# Altium schematic sheet PWR.SchDoc(PWR)
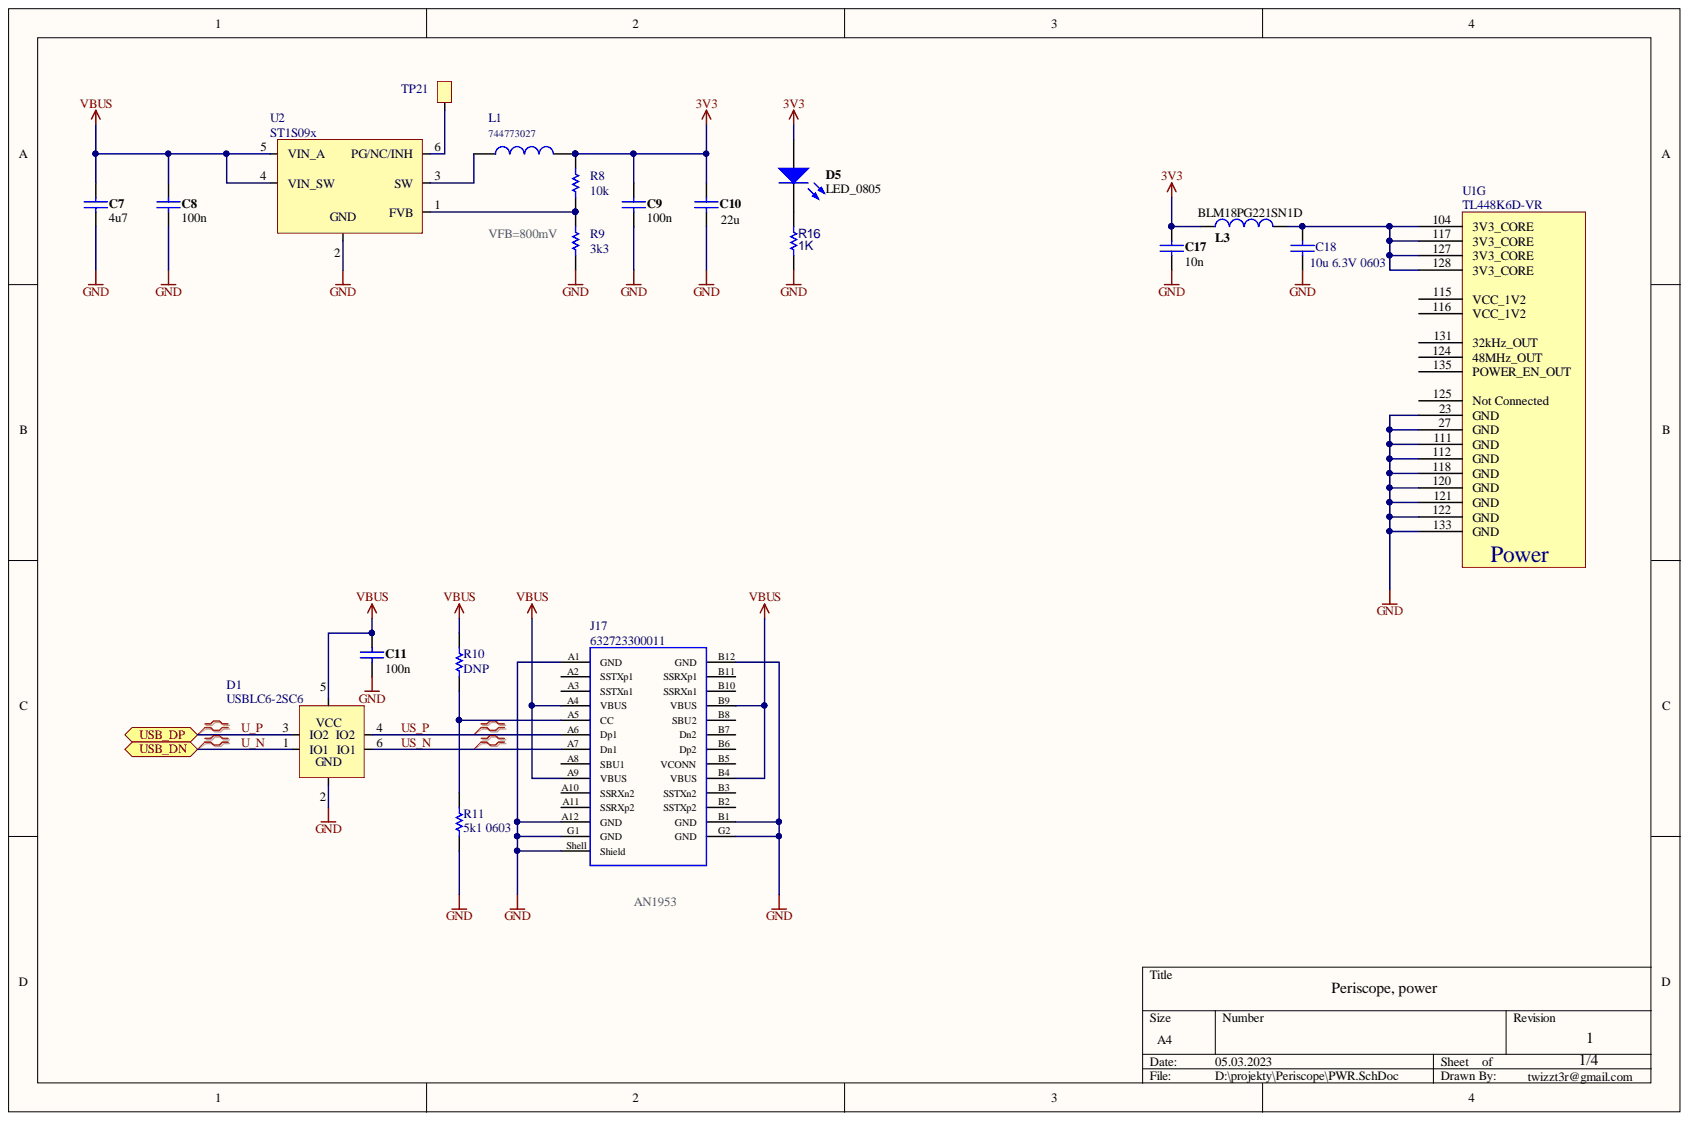
[diagram p0: PWR.SchDoc]

## Parts
C7  value 4u7  [C_0603]  (hidden comment: 4u7 10V 0603)
C8  value 100n  [C_0603]  (hidden comment: 100n 25V 0603)
C9  value 100n  [C_0603]  (hidden comment: 100n 25V 0603)
C10  value 22u  [C_0805]  (hidden comment: 22u 6V3 0805)
C11  value 100n  [C_0603]  (hidden comment: 100n 25V 0603)
C17  value 10n  [C_0603]  (hidden comment: 10n 50v 0603)  (net tie)
C18  10u 6.3V 0603  [WCAP-CSGP_0603_H=0.8mm_Reflow]
D1  USBLC6-2SC6  [SOT666]
D5  LED_0805  [D_0805]
J17  632723300011  [632723300011]
L1  744773027  [WE-PD2_4532]
L3  BLM18PG221SN1D  [R_0805]
R8  value 10k  [R_0603]  (hidden comment: 10k 0603)
R9  value 3k3  [R_0603]  (hidden comment: 3k3 0603)
R10  DNP  [R_0603]
R11  5k1 0603  [R_0603]
R16  VALUE_TXT: 1K  [R_0603]  (hidden comment: 1k 0603)
TP21  testpoint  [TP_round_1mm]  (value not drawn)
U1  TL448K6D-VR  [TL448K6D-VR]  (part G)
U2  ST1S09x  [QFN6]

## Ports
USB_DN, USB_DP

## Nets
3V3: C9.2 C10.2 C17.2 D5.1 L1.2 L3.2 R8.2
GND: C7.1 C8.1 C9.1 C10.1 C11.1 C17.1 C18.1 D1.2 J17.A1(GND) J17.A12(GND) J17.B1(GND) J17.B12(GND) J17.G1(GND) J17.G2(GND) J17.Shell(Shield) R9.1 R11.1 R16.1 U1.23(GND) U1.27(GND) U1.111(GND) U1.112(GND) U1.118(GND) U1.120(GND) U1.121(GND) U1.122(GND) U1.133(GND) U2.2
VBUS: C7.2 C8.2 C11.2 D1.5 J17.A4(VBUS) J17.A9(VBUS) J17.B4(VBUS) J17.B9(VBUS) R10.2 U2.4(VIN_SW) U2.5(VIN_A)
U_N: D1.1(IO1) ; port USB_DN
U_P: D1.3(IO2) ; port USB_DP
US_N: D1.6(IO1) J17.A7(Dn1)
US_P: D1.4(IO2) J17.A6(Dp1)
NetC18_2: C18.2 L3.1 U1.104(3V3_CORE) U1.117(3V3_CORE) U1.127(3V3_CORE) U1.128(3V3_CORE)
NetD5_2: D5.2 R16.2
NetJ17_A5: J17.A5(CC) R10.1 R11.2
NetL1_1: L1.1 U2.3(SW)
NetR8_1: R8.1 R9.2 U2.1(FVB)
NetTP21_1: TP21.1 U2.6(PG/NC/INH)

Not connected: J17 A2(SSTXp1) A3(SSTXn1) A8(SBU1) A10(SSRXn2) A11(SSRXp2) B2(SSTXp2) B3(SSTXn2) B5(VCONN) B6(Dp2) B7(Dn2) B8(SBU2) B10(SSRXn1) B11(SSRXp1); U1 115(VCC_1V2) 116(VCC_1V2) 124(48MHz_OUT) 125(Not_Connected) 131(32kHz_OUT) 135(POWER_EN_OUT)

## Library data of the parts
C7 = C_4u7_10v_0603: 4u7 10v; mfg_pn: CGB3B1X5R1A475M055AC; Library Name: SCH.SchLib; Component Kind: Standard
C8 C9 C11 = C_100n_25V_0603: 100n 25V 0603 X7R; mfg_pn: 885012206071; Library Name: SCH.SchLib; Component Kind: Standard
C10 = C_0805: 1206; Library Name: SCH.SchLib; Component Kind: Standard
C17 = C_10n_50v_0603: mfg_pn: CL10B103KB8NNNC; Rated Voltage: 50v; Library Name: SCH.SchLib; Description: 10n 50V 0603
C18 = C_10u_6V3_0603: WCAP-CSGP Multilayer Ceramic Chip Capacitor, General Purpose, size 0603, X5R, 10uF, 6.3V; Manufacturer Part Number: 885012106006; Manufacturer: Wurth Elektronik; Technical Reference: X5R0603106M6R3DFCT10000; Rated Voltage: 6.3VDC; Isolation Resistance  min.: 0.01GOhm; Dissipation Factor max.: 10%; Capacitance Tolerance: +/-20%; Size: 0603; Q-Factor min.: -; Published Date: April 2021; Packaging: Tape and Reel; Operating Temperature: -55 to +85degC; Mounting Technology: SMT; Library Name: SCH.SchLib; Height: 0.8mm; ComponentLink2URL: https://www.we-online.com/catalog/datasheet/885012106006.pdf; ComponentLink2Description: Datasheet; ComponentLink1URL: http://www.we-online.de; ComponentLink1Description: Manufacturer; Component Kind: Standard; Ceramic Type: X5R; Capacitance: 10uF
D5 = LED_0805: LED; mfg_pn: LL-S170VC-V1-1B; Library Name: SCH.SchLib; Component Kind: Standard
J17 = 632723300011: USB 3.1 Type C, Receptacle, Horizontal, THR; Manufacturer: Wurth Elektronik; Working Voltage: 20VAC; Rated Current: 5A; Pin Number: 24; Interface Type: Type C; Type: Horizontal; Published Date: August 2020; Packaging: Tape and Reel; Operating Temperature: -40 to +105degC; Mounting Technology: THR; Manufacturer Part Number: 632723300011; Library Name: SCH.SchLib; Gender: Receptacle; ComponentLink2URL: https://katalog.we-online.com/em/datasheet/632723300011.pdf; ComponentLink2Description: Datasheet; ComponentLink1URL: http://www.we-online.de; ComponentLink1Description: Manufacturer; Component Kind: Standard; Application: USB 3.1
L1 = 744773027: WE-PD2 SMT Power Inductor, size 4532, 2.7uH, 2.25A; Manufacturer: Wurth Elektronik; Self Resonant Frequency: 72MHz; Saturation Current: 2.97A; DC Resistance typ.: 0.039Ohm; DC Resistance max.: 0.079Ohm; Size: 4532; Rated Current: 2.25A; Published Date: April 2020; Packaging: Tape and Reel; Operating Temperature: -40 to +125degC; Mounting Technology: SMT; Manufacturer Part Number: 744773027; Library Name: SCH.SchLib; Inductance: 2.7uH; ComponentLink2URL: https://www.we-online.com/catalog/datasheet/744773027.pdf; ComponentLink2Description: Datasheet; ComponentLink1URL: http://www.we-online.de; ComponentLink1Description: Manufacturer; Component Kind: Standard
L3 = L_0603: SMD-Multilayer Inductor; PackageVersion: 2009; PackageReference: SMD-0603; Library Name: SCH.SchLib; Component Kind: Standard
R8-R11 = R_0603: Library Name: SCH.SchLib; Component Kind: Standard
R16 = R_1K_0603: SMD RES 1K-1%-1/10W; Manufacturer: YAGEO; Package: 0603; Library Name: SCH.SchLib; Component Kind: Standard
TP21 = TP_1: Library Name: SCH.SchLib; Component Kind: Standard
D1 = USBLC6-2SC6: Library Name: SCH.SchLib; Component Kind: Standard
U1 = TL448K6D-VR: Library Name: SCH.SchLib; Component Kind: Standard
U2 = ST1S09x: Library Name: SCH.SchLib; Component Kind: Standard
